# Altium schematic sheet cwh_fpga_io.SchDoc
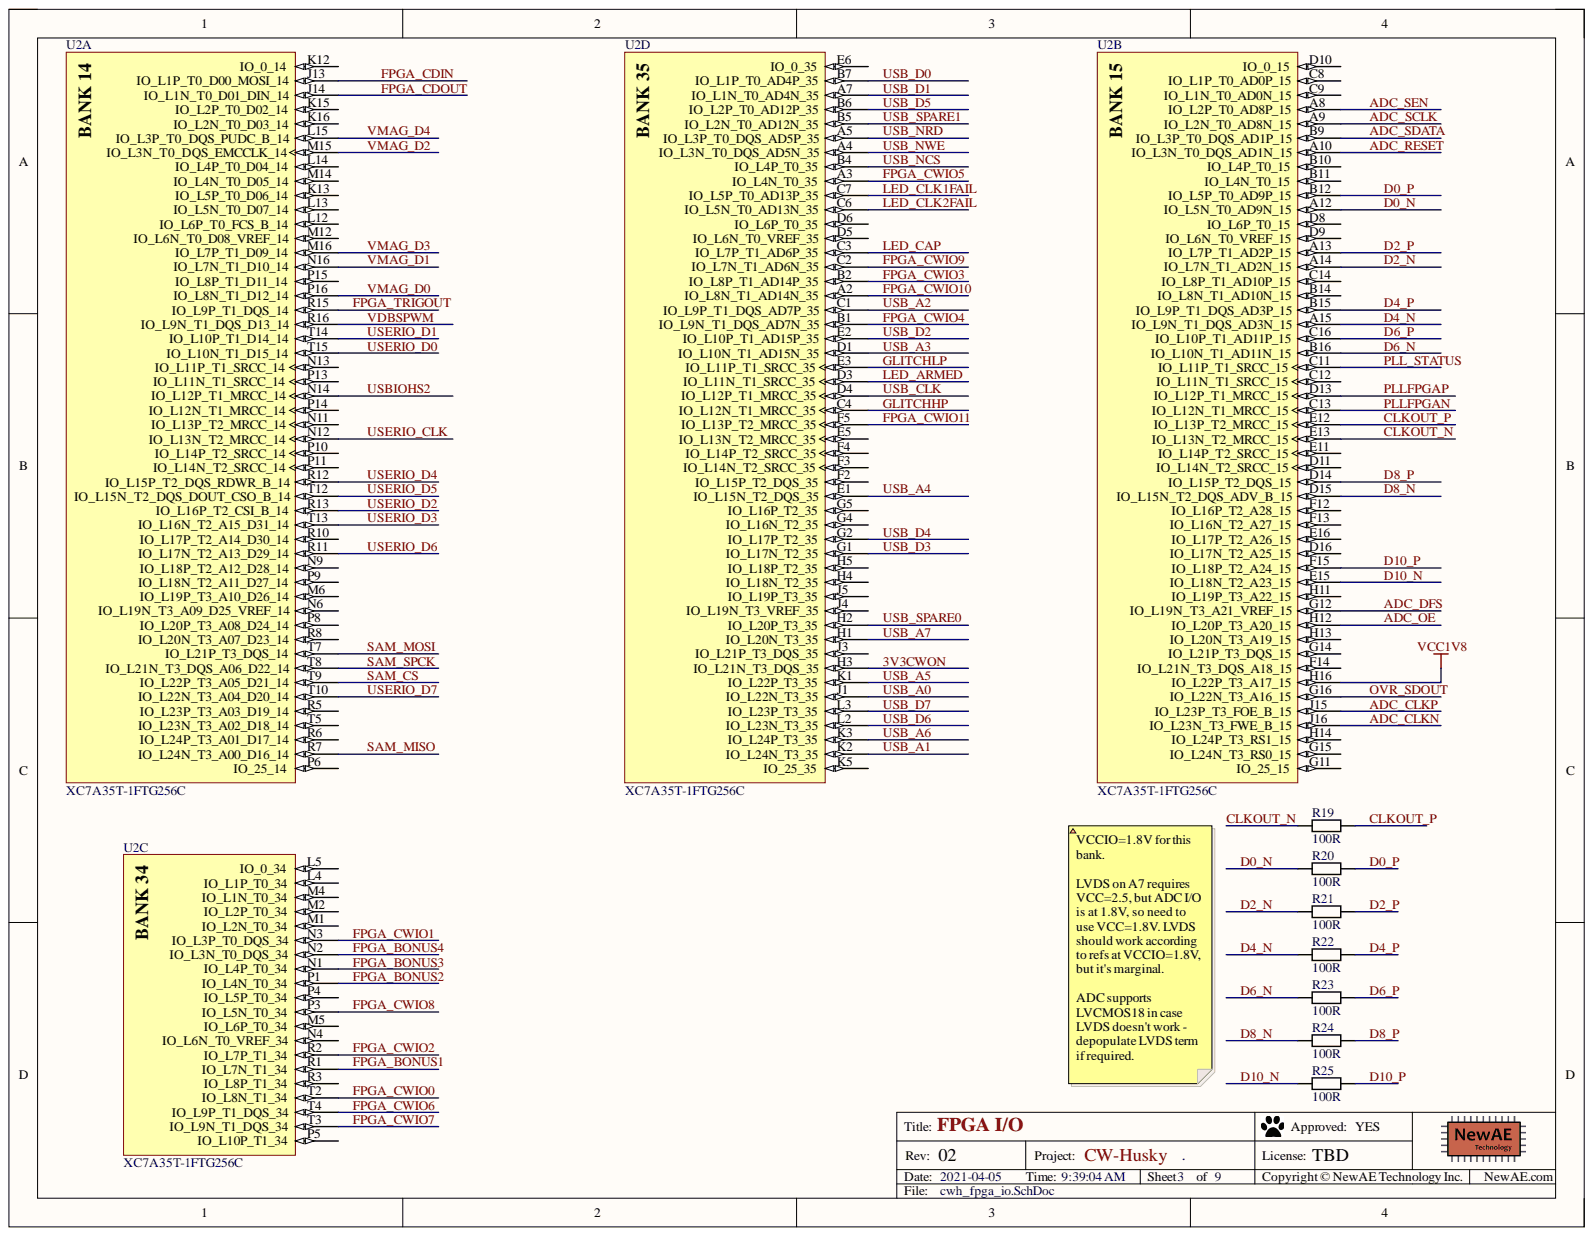
[diagram p0: cwh_fpga_io.SchDoc]

## Parts
R19  100R  [0402]
R20  100R  [0402]
R21  100R  [0402]
R22  100R  [0402]
R23  100R  [0402]
R24  100R  [0402]
R25  100R  [0402]
U2  XC7A35T-1FTG256C  [FTG256]  (parts A,B,C,D)

## Nets
VCC1V8: U2.H16(IO_L22P_T3_A17_15)
3V3CWON: U2.H3(IO_L21N_T3_DQS_35)
ADC_CLKN: U2.J16(IO_L23N_T3_FWE_B_15)
ADC_CLKP: U2.J15(IO_L23P_T3_FOE_B_15)
ADC_DFS: U2.G12(IO_L19N_T3_A21_VREF_15)
ADC_OE: U2.H12(IO_L20P_T3_A20_15)
ADC_RESET: U2.A10(IO_L3N_T0_DQS_AD1N_15)
ADC_SCLK: U2.A9(IO_L2N_T0_AD8N_15)
ADC_SDATA: U2.B9(IO_L3P_T0_DQS_AD1P_15)
ADC_SEN: U2.A8(IO_L2P_T0_AD8P_15)
CLKOUT_N: R19.1 U2.E13(IO_L13N_T2_MRCC_15)
CLKOUT_P: R19.2 U2.E12(IO_L13P_T2_MRCC_15)
D0_N: R20.1 U2.A12(IO_L5N_T0_AD9N_15)
D0_P: R20.2 U2.B12(IO_L5P_T0_AD9P_15)
D2_N: R21.1 U2.A14(IO_L7N_T1_AD2N_15)
D2_P: R21.2 U2.A13(IO_L7P_T1_AD2P_15)
D4_N: R22.1 U2.A15(IO_L9N_T1_DQS_AD3N_15)
D4_P: R22.2 U2.B15(IO_L9P_T1_DQS_AD3P_15)
D6_N: R23.1 U2.B16(IO_L10N_T1_AD11N_15)
D6_P: R23.2 U2.C16(IO_L10P_T1_AD11P_15)
D8_N: R24.1 U2.D15(IO_L15N_T2_DQS_ADV_B_15)
D8_P: R24.2 U2.D14(IO_L15P_T2_DQS_15)
D10_N: R25.1 U2.E15(IO_L18N_T2_A23_15)
D10_P: R25.2 U2.F15(IO_L18P_T2_A24_15)
FPGA_BONUS1: U2.R1(IO_L7N_T1_34)
FPGA_BONUS2: U2.P1(IO_L4N_T0_34)
FPGA_BONUS3: U2.N1(IO_L4P_T0_34)
FPGA_BONUS4: U2.N2(IO_L3N_T0_DQS_34)
FPGA_CDIN: U2.J13(IO_L1P_T0_D00_MOSI_14)
FPGA_CDOUT: U2.J14(IO_L1N_T0_D01_DIN_14)
FPGA_CWIO0: U2.T2(IO_L8N_T1_34)
FPGA_CWIO1: U2.N3(IO_L3P_T0_DQS_34)
FPGA_CWIO2: U2.R2(IO_L7P_T1_34)
FPGA_CWIO3: U2.B2(IO_L8P_T1_AD14P_35)
FPGA_CWIO4: U2.B1(IO_L9N_T1_DQS_AD7N_35)
FPGA_CWIO5: U2.A3(IO_L4N_T0_35)
FPGA_CWIO6: U2.T4(IO_L9P_T1_DQS_34)
FPGA_CWIO7: U2.T3(IO_L9N_T1_DQS_34)
FPGA_CWIO8: U2.P3(IO_L5N_T0_34)
FPGA_CWIO9: U2.C2(IO_L7N_T1_AD6N_35)
FPGA_CWIO10: U2.A2(IO_L8N_T1_AD14N_35)
FPGA_CWIO11: U2.F5(IO_L13P_T2_MRCC_35)
FPGA_TRIGOUT: U2.R15(IO_L9P_T1_DQS_14)
GLITCHHP: U2.C4(IO_L12N_T1_MRCC_35)
GLITCHLP: U2.E3(IO_L11P_T1_SRCC_35)
LED_ARMED: U2.D3(IO_L11N_T1_SRCC_35)
LED_CAP: U2.C3(IO_L7P_T1_AD6P_35)
LED_CLK1FAIL: U2.C7(IO_L5P_T0_AD13P_35)
LED_CLK2FAIL: U2.C6(IO_L5N_T0_AD13N_35)
OVR_SDOUT: U2.G16(IO_L22N_T3_A16_15)
PLL_STATUS: U2.C11(IO_L11P_T1_SRCC_15)
PLLFPGAN: U2.C13(IO_L12N_T1_MRCC_15)
PLLFPGAP: U2.D13(IO_L12P_T1_MRCC_15)
SAM_CS: U2.T9(IO_L22P_T3_A05_D21_14)
SAM_MISO: U2.R7(IO_L24N_T3_A00_D16_14)
SAM_MOSI: U2.T7(IO_L21P_T3_DQS_14)
SAM_SPCK: U2.T8(IO_L21N_T3_DQS_A06_D22_14)
USB_A0: U2.J1(IO_L22N_T3_35)
USB_A1: U2.K2(IO_L24N_T3_35)
USB_A2: U2.C1(IO_L9P_T1_DQS_AD7P_35)
USB_A3: U2.D1(IO_L10N_T1_AD15N_35)
USB_A4: U2.E1(IO_L15N_T2_DQS_35)
USB_A5: U2.K1(IO_L22P_T3_35)
USB_A6: U2.K3(IO_L24P_T3_35)
USB_A7: U2.H1(IO_L20N_T3_35)
USB_CLK: U2.D4(IO_L12P_T1_MRCC_35)
USB_D0: U2.B7(IO_L1P_T0_AD4P_35)
USB_D1: U2.A7(IO_L1N_T0_AD4N_35)
USB_D2: U2.E2(IO_L10P_T1_AD15P_35)
USB_D3: U2.G1(IO_L17N_T2_35)
USB_D4: U2.G2(IO_L17P_T2_35)
USB_D5: U2.B6(IO_L2P_T0_AD12P_35)
USB_D6: U2.L2(IO_L23N_T3_35)
USB_D7: U2.L3(IO_L23P_T3_35)
USB_NCS: U2.B4(IO_L4P_T0_35)
USB_NRD: U2.A5(IO_L3P_T0_DQS_AD5P_35)
USB_NWE: U2.A4(IO_L3N_T0_DQS_AD5N_35)
USB_SPARE0: U2.H2(IO_L20P_T3_35)
USB_SPARE1: U2.B5(IO_L2N_T0_AD12N_35)
USBIOHS2: U2.N14(IO_L12P_T1_MRCC_14)
USERIO_CLK: U2.N12(IO_L13N_T2_MRCC_14)
USERIO_D0: U2.T15(IO_L10N_T1_D15_14)
USERIO_D1: U2.T14(IO_L10P_T1_D14_14)
USERIO_D2: U2.R13(IO_L16P_T2_CSI_B_14)
USERIO_D3: U2.T13(IO_L16N_T2_A15_D31_14)
USERIO_D4: U2.R12(IO_L15P_T2_DQS_RDWR_B_14)
USERIO_D5: U2.T12(IO_L15N_T2_DQS_DOUT_CSO_B_14)
USERIO_D6: U2.R11(IO_L17N_T2_A13_D29_14)
USERIO_D7: U2.T10(IO_L22N_T3_A04_D20_14)
VDBSPWM: U2.R16(IO_L9N_T1_DQS_D13_14)
VMAG_D0: U2.P16(IO_L8N_T1_D12_14)
VMAG_D1: U2.N16(IO_L7N_T1_D10_14)
VMAG_D2: U2.M15(IO_L3N_T0_DQS_EMCCLK_14)
VMAG_D3: U2.M16(IO_L7P_T1_D09_14)
VMAG_D4: U2.L15(IO_L3P_T0_DQS_PUDC_B_14)

Not connected: U2 B10(IO_L4P_T0_15) B11(IO_L4N_T0_15) B14(IO_L8N_T1_AD10N_15) C8(IO_L1P_T0_AD0P_15) C9(IO_L1N_T0_AD0N_15) C12(IO_L11N_T1_SRCC_15) C14(IO_L8P_T1_AD10P_15) D5(IO_L6N_T0_VREF_35) D6(IO_L6P_T0_35) D8(IO_L6P_T0_15) D9(IO_L6N_T0_VREF_15) D10(IO_0_15) D11(IO_L14N_T2_SRCC_15) D16(IO_L17N_T2_A25_15) E5(IO_L13N_T2_MRCC_35) E6(IO_0_35) E11(IO_L14P_T2_SRCC_15) E16(IO_L17P_T2_A26_15) F2(IO_L15P_T2_DQS_35) F3(IO_L14N_T2_SRCC_35) F4(IO_L14P_T2_SRCC_35) F12(IO_L16P_T2_A28_15) F13(IO_L16N_T2_A27_15) F14(IO_L21N_T3_DQS_A18_15) G4(IO_L16N_T2_35) G5(IO_L16P_T2_35) G11(IO_25_15) G14(IO_L21P_T3_DQS_15) G15(IO_L24N_T3_RS0_15) H4(IO_L18N_T2_35) H5(IO_L18P_T2_35) H11(IO_L19P_T3_A22_15) H13(IO_L20N_T3_A19_15) H14(IO_L24P_T3_RS1_15) J3(IO_L21P_T3_DQS_35) J4(IO_L19N_T3_VREF_35) J5(IO_L19P_T3_35) K5(IO_25_35) K12(IO_0_14) K13(IO_L5P_T0_D06_14) K15(IO_L2P_T0_D02_14) K16(IO_L2N_T0_D03_14) L4(IO_L1P_T0_34) L5(IO_0_34) L12(IO_L6P_T0_FCS_B_14) L13(IO_L5N_T0_D07_14) L14(IO_L4P_T0_D04_14) M1(IO_L2N_T0_34) M2(IO_L2P_T0_34) M4(IO_L1N_T0_34) M5(IO_L6P_T0_34) M6(IO_L19P_T3_A10_D26_14) M12(IO_L6N_T0_D08_VREF_14) M14(IO_L4N_T0_D05_14) N4(IO_L6N_T0_VREF_34) N6(IO_L19N_T3_A09_D25_VREF_14) N9(IO_L18P_T2_A12_D28_14) N11(IO_L13P_T2_MRCC_14) N13(IO_L11P_T1_SRCC_14) P4(IO_L5P_T0_34) P5(IO_L10P_T1_34) P6(IO_25_14) P8(IO_L20P_T3_A08_D24_14) P9(IO_L18N_T2_A11_D27_14) P10(IO_L14P_T2_SRCC_14) P11(IO_L14N_T2_SRCC_14) P13(IO_L11N_T1_SRCC_14) P14(IO_L12N_T1_MRCC_14) P15(IO_L8P_T1_D11_14) R3(IO_L8P_T1_34) R5(IO_L23P_T3_A03_D19_14) R6(IO_L24P_T3_A01_D17_14) R8(IO_L20N_T3_A07_D23_14) R10(IO_L17P_T2_A14_D30_14) T5(IO_L23N_T3_A02_D18_14)

## Library data of the parts
R19-R25 = RCHIP-100R-0402: 100R res, 0402, 1%, 1/16W; Manufacture 1: Yageo; Manufacture Part Number 1: RC0402FR-07100RL; Case-Metric: 1005; Component Group: Resistor; Database Table Name: main; Rated Power: 0.063W; Rated Voltage: 75VDC; Case-EIA: 0402; Component Kind: Standard; Component Type: Standard; Library Name: NewAE_AltiumLib.DBLib; Mounting Technology: SMT; Part Number: RCHIP-100R-0402; Pin Count: 2; Supplier 1: Digi-Key; Supplier Part Number 1: 311-100LRCT-ND; Temperature Range: -55C to 155C; Tolerance: 1%; Value: 100R
U2 = CMP-0383-00028-1: Artix-7 FPGA, 170 User I/Os, 0 GTP, 256-Ball BGA, Speed Grade 1, Commercial Grade, Pb-Free; Manufacturer: Xilinx; Available User I/O: 170; CMTs: 5; GTP: 0; Logic Cells: 33280; Speed Grades: 1; Total Block RAM: 1800 Kb; Component Kind: Standard; ComponentLink1Description: Manufacturer URL; ComponentLink1URL: http://www.xilinx.com/; ComponentLink2Description: Datasheet; ComponentLink2URL: http://www.xilinx.com/support/documentation/data_sheets/ds180_7Series_Overview.pdf; ComponentLink3Description: Pinout; ComponentLink3URL: http://www.xilinx.com/support/documentation/user_guides/ug475_7Series_Pkg_Pinout.pdf; ComponentLink4Description: Package Specification; ComponentLink4URL: http://www.xilinx.com/support/documentation/user_guides/ug475_7Series_Pkg_Pinout.pdf; DatasheetVersion: Rev. 1.15; Library Name: Altium Content Vault; Maximum Distributed RAM: 400 Kb; Mounting Technology: Surface Mount; Operating Temperature Range: Commercial; PackageDescription: 256-Ball Wire-Bond Fine-Pitch Thin BGA, Body 17 x 17 mm, Pitch 1 mm; PackageReference: FTG-256; PackageVersion: Rev. 1.11; PartNumber: XC7A35T-1FTG256C
